# Altium schematic sheet CONNECTROS.SchDoc(CONNECTROS)
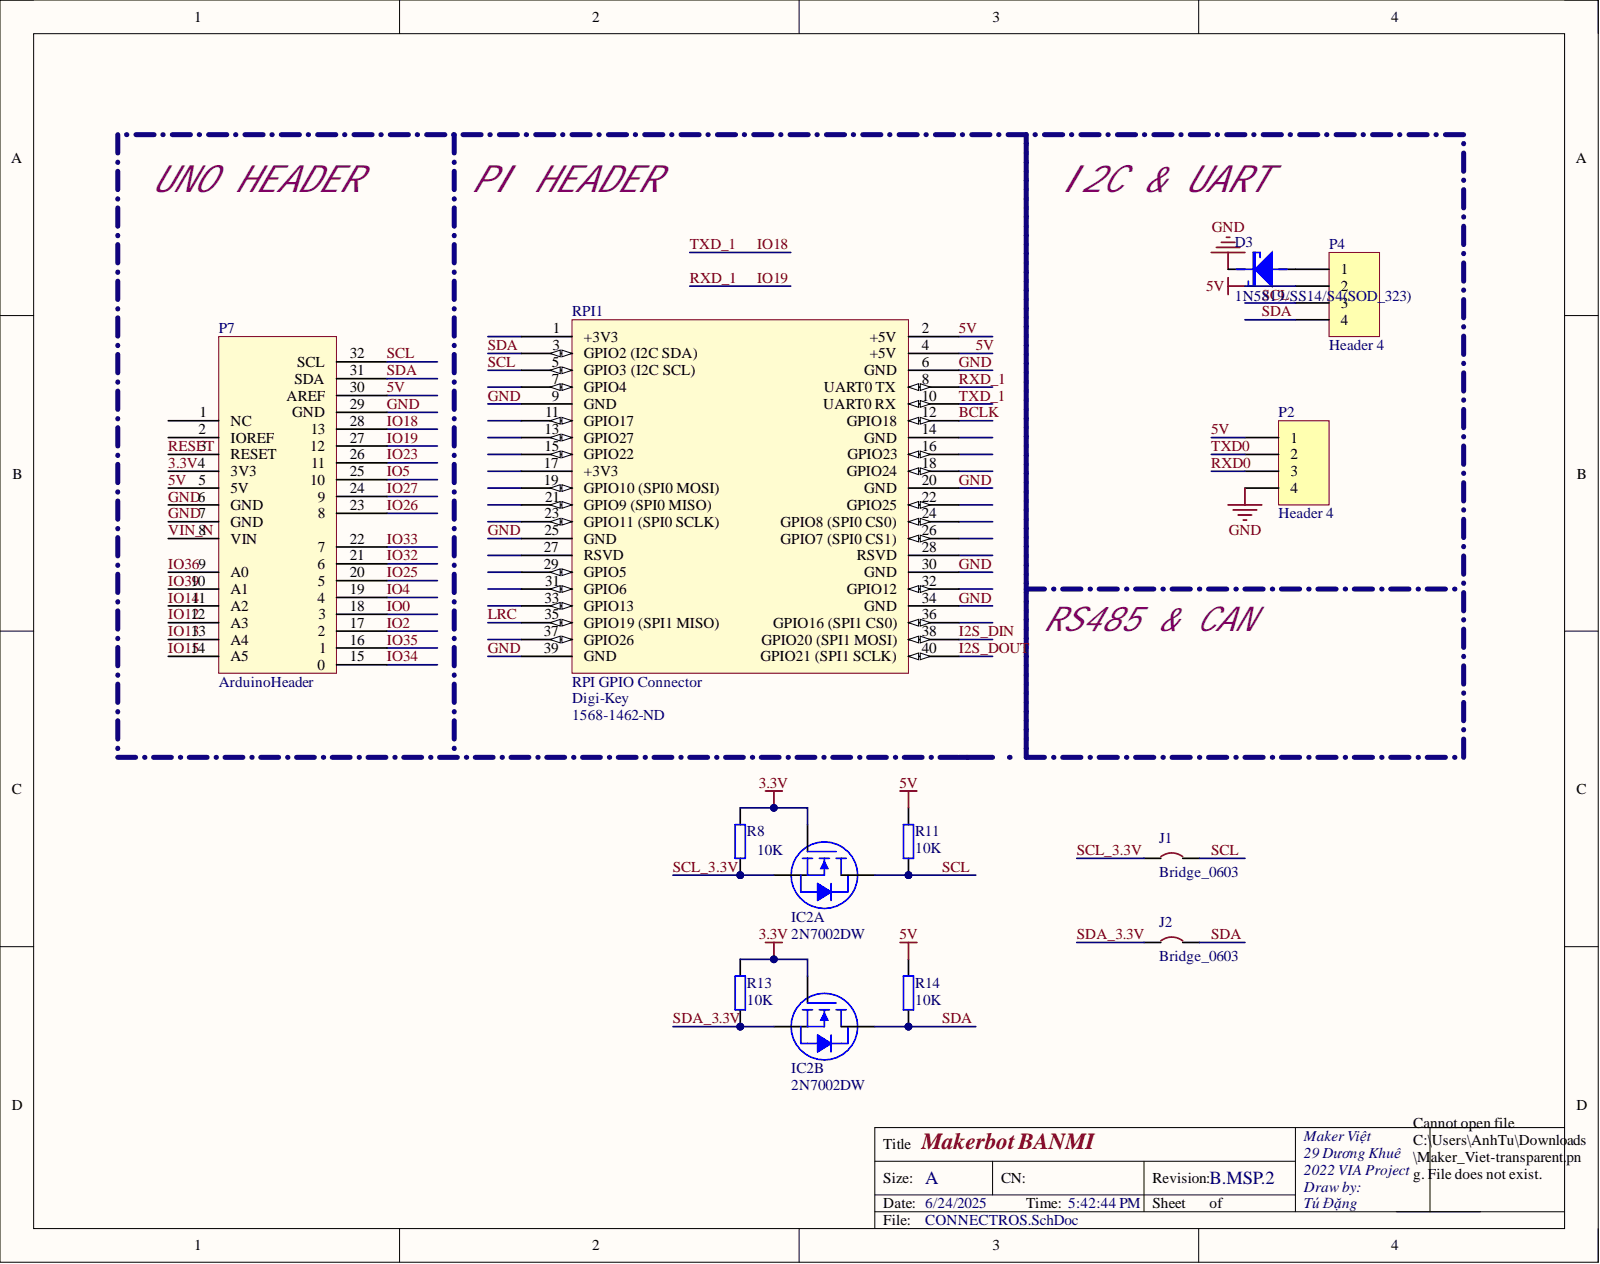
[diagram p0: CONNECTROS.SchDoc]

## Parts
D3  1N5819/SS14/S4(SOD_323)
IC2  2N7002DW  (parts A,B)
J1  Bridge_0603
J2  Bridge_0603
P2  Header 4
P4  Header 4
P7  ArduinoHeader
R8  value 10K  (hidden comment: Resistor)
R11  value 10K  (hidden comment: Resistor)
R13  value 10K  (hidden comment: Resistor)
R14  value 10K  (hidden comment: Resistor)
RPI1  RPI GPIO Connector  Accessory Type: Connector  Supplier 1: Digi-Key

## Nets
3.3V: IC2.2 IC2.5 P7.4(3V3) R8.1 R13.2
5V: P2.1 P4.2 P7.5(5V) P7.30(AREF) R11.1 R14.1 RPI1.2(+5V) RPI1.4(+5V)
BCLK: RPI1.12(GPIO18)
GND: D3.2 P2.4 P7.6(GND) P7.7(GND) P7.29(GND) RPI1.6(GND) RPI1.9(GND) RPI1.20(GND) RPI1.25(GND) RPI1.30(GND) RPI1.34(GND) RPI1.39(GND)
I2S_DIN: RPI1.38(GPIO20_(SPI1_MOSI))
I2S_DOUT: RPI1.40(GPIO21_(SPI1_SCLK))
IO0: P7.18
IO2: P7.17
IO4: P7.19
IO5: P7.25
IO12: P7.12(A3)
IO13: P7.13(A4)
IO14: P7.11(A2)
IO15: P7.14(A5)
IO18: P7.28 RPI1.10(UART0_RX)
IO19: P7.27 RPI1.8(UART0_TX)
IO23: P7.26
IO25: P7.20
IO26: P7.23
IO27: P7.24
IO32: P7.21
IO33: P7.22
IO34: P7.15
IO35: P7.16
IO36: P7.9(A0)
IO39: P7.10(A1)
LRC: RPI1.35(GPIO19_(SPI1_MISO))
RESET: P7.3(RESET)
RXD0: P2.3
SCL: IC2.6 J1.2 P4.3 P7.32(SCL) R11.2 RPI1.5(GPIO3_(I2C_SCL))
SCL_3.3V: IC2.1 J1.1 R8.2
SDA: IC2.3 J2.2 P4.4 P7.31(SDA) R14.2 RPI1.3(GPIO2_(I2C_SDA))
SDA_3.3V: IC2.4 J2.1 R13.1
TXD0: P2.2
VIN_N: P7.8(VIN)
NetD3_1: D3.1 P4.1

Not connected: P7 1(NC) 2(IOREF); RPI1 1(+3V3) 7(GPIO4) 11(GPIO17) 13(GPIO27) 14(GND) 15(GPIO22) 16(GPIO23) 17(+3V3) 18(GPIO24) 19(GPIO10_(SPI0_MOSI)) 21(GPIO9_(SPI0_MISO)) 22(GPIO25) 23(GPIO11_(SPI0_SCLK)) 24(GPIO8_(SPI0_CS0)) 26(GPIO7_(SPI0_CS1)) 27(RSVD) 28(RSVD) 29(GPIO5) 31(GPIO6) 32(GPIO12) 33(GPIO13) 36(GPIO16_(SPI1_CS0)) 37(GPIO26)

## Library data of the parts
D3 = SMT_DIODE_SCHOTTKY_SOD_323: Surface mount schottky diode SOD-323(0805) Package; Component Kind: Standard; Library Name: NODEMCU_DEVKIT_V1.0.IntLib
IC2 = ON SEMI DUAL MOSFET N-CH SOT363: Component Kind: Standard; Description: 2N7002DW; Library Name: SCH - FET - N-CH - ON SEMI DUAL MOSFET N-CH SOT363.SCHLIB
P2 P4 = Header 4: Header, 4-Pin; Component Kind: Standard; LatestRevisionDate: 17-Jul-2002; LatestRevisionNote: Re-released for DXP Platform.; Library Name: Miscellaneous Connectors.IntLib; Publisher: Altium Limited
R8 R11 R13 R14 = RES-SM-0603-10K: RES SM 0603 10K; Component Kind: Standard; Library Name: electronpowered.SchLib; Supplier 1: Farnell; Supplier 2: Digi-Key; Supplier Part Number 1: 9330399; Supplier Part Number 2: 541-10.0KHCT-ND
RPI1 = rpi connector: GPIO Header for Raspberry Pi A+/B+/Pi 2/Pi 3 - 2x20; Manufacturer: SparkFun Electronics; Manufacturer Part Number: PRT-14017; Nils Minor: author; nilsminor@web.de: mail; Category: Development Boards, Kits, Programmers; Component Kind: Standard; ComponentLink1Description: https://cdn.sparkfun.com/datasheets/Dev/RaspberryPi/12313.pdf; ComponentLink1URL: https://cdn.sparkfun.com/datasheets/Dev/RaspberryPi/12313.pdf; For Use With/Related Products: Raspberry Pi; Library Name: rpi.SchLib; Part Status: Active; Pricing 1: 1=1.84 (EUR); RoHS: RoHS Compliant; Stock 1: 150; Supplier Part Number 1: 1568-1462-ND
J1 J2 = Bridge_0603: Component Kind: Standard; Library Name: Keyboard.schlib
P7 = ArduinoHeader: Component Kind: Standard; Library Name: electronpowered.SchLib
